# Altium schematic sheet DD_Current_Sense.SchDoc(DD_Current_Sense)
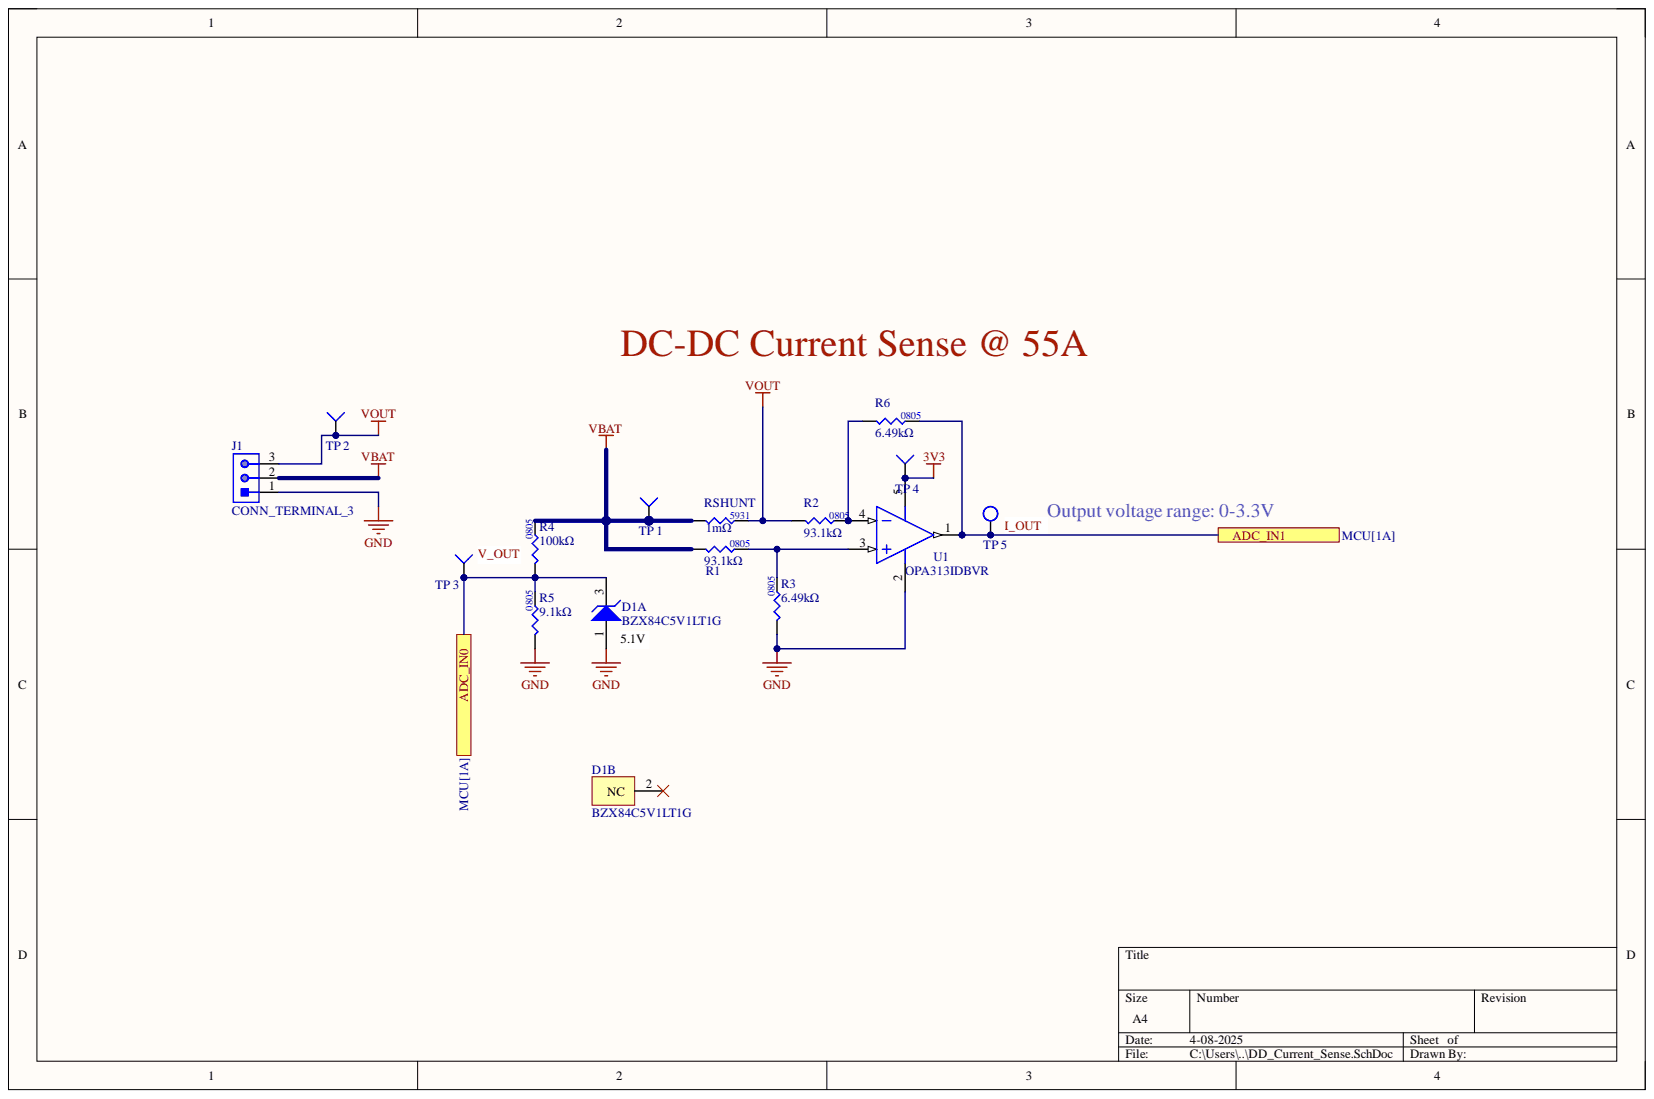
[diagram p0: DD_Current_Sense.SchDoc]

## Parts
D1  BZX84C5V1LT1G  [ONSC-SOT-23-3-318-08_V]  (parts A,B)
J1  CONN_TERMINAL_3  [WJ127-5.0-3P]
R1  value 93.1kΩ  Package/Footprint: 0805  [RES0805]  (hidden comment: RES_100Ω_0805_1%)
R2  value 93.1kΩ  Package/Footprint: 0805  [RES0805]  (hidden comment: RES_100Ω_0805_1%)
R3  value 6.49kΩ  Package/Footprint: 0805  [RES0805]  (hidden comment: RES_9.1kΩ_0805_5%)
R4  value 100kΩ  [RES0805]  (hidden comment: RES_100kΩ_0805_0.5%)
R5  value 9.1kΩ  Package: 0805  [RES0805]  (hidden comment: RES_9.1kΩ_0805_5%)
R6  value 6.49kΩ  Package/Footprint: 0805  [RES0805]  (hidden comment: RES_9.1kΩ_0805_5%)
RSHUNT  value 1mΩ  Package: 5931  [RES-5931]  (hidden comment: RES_1mΩ_5931_1%)
TP_1  TESTPOINT_1MM_CIRCLE  [TESTPOINT_CIRCLE_1MM]  (value not drawn)  (no BOM)
TP_2  TESTPOINT_1MM_CIRCLE  [TESTPOINT_CIRCLE_1MM]  (value not drawn)  (no BOM)
TP_3  TESTPOINT_1MM_CIRCLE  [TESTPOINT_CIRCLE_1MM]  (value not drawn)  (no BOM)
TP_4  TESTPOINT_1MM_CIRCLE  [TESTPOINT_CIRCLE_1MM]  (value not drawn)  (no BOM)
TP_5  TESTPOINT_LOOP  [TESTPOINT_LOOP]  (value not drawn)
U1  Manufacturer Part Number 1: OPA313IDBVR  [DBV0005A_M]  (hidden comment: OPAMP_OPA313IDBVR)

## Ports
ADC_IN0, ADC_IN1

## Nets
3V3: TP_4.1 U1.5
GND: D1.1 J1.1 R3.1 R5.1 U1.2
VBAT: J1.2 R1.1 R4.2 RSHUNT.1 TP_1.1
VOUT: J1.3 R2.2 RSHUNT.2 TP_2.1
ADC_IN0: D1.3 R4.1 R5.2 TP_3.1 ; port ADC_IN0
ADC_IN1: R6.2 TP_5.1 U1.1 ; port ADC_IN1
NetR1_2: R1.2 R3.2 U1.3
NetR2_1: R2.1 R6.1 U1.4

Not connected: D1 2(NC)

## Library data of the parts
D1 = CMP-1060-00946-1: Zener Voltage Regulator, 225 mW, 3-Pin SOT-23, Pb-Free, Tape and Reel; Manufacturer: On Semiconductor; Component Kind: Standard; ComponentLink1Description: Manufacturer URL; ComponentLink1URL: http://www.onsemi.com/; ComponentLink2Description: Datasheet; ComponentLink2URL: http://www.onsemi.com/pub_link/Collateral/BZX84C2V4LT1-D.PDF; ComponentLink3Description: Package Specification; ComponentLink3URL: http://www.onsemi.cn/pub_link/Collateral/318-08.PDF; DatasheetVersion: Rev. 17; Library Name: Altium Content Vault; Mounting Technology: Surface Mount; PackageDescription: 3-Pin Small Outline Transistor, Body 2.92 x 1.3 mm, Pitch 0.95 mm; PackageReference: SOT-23-3-318-08; PackageVersion: Rev. AP, 11/2009; Packing: Tape and Reel; PartNumber: BZX84C5V1LT1G; RoHS: Pb-Free
J1 = CONN_TERMINAL_3: Header, 3-Pin; Manufacturer 1: Ningbo Kangnex; Component Kind: Standard; Datasheet: https://datasheet.lcsc.com/lcsc/2107141401_Ningbo-Kangnex-Elec-WJ127-5-0-3P_C8393.pdf; LCSC Number: C8393; Manufaturer Part Number 1: WJ127-5.0-3P; Supplier 1: LCSC; Library Name: UWRT_Schematic_Library_2020.SchLib
R1 R2 = RES_93.1kΩ_0805_1%: RES 93.1K OHM 1% 1/8W 0805; Manufacturer 1: Panasonic Electronic Components; Manufacturer Part Number 1: ERJ-6ENF9312V; Component Kind: Standard; LCSC Part #: C17869; Mounting Type: SMD; Supplier 1: Digi-Key; Supplier Part Number 1: P93.1KCCT-ND; Library Name: UWRT_Schematic_Library_2020.SchLib
R3 R6 = RES_6.49kΩ_0805_1%: RES 6.49K OHM 1% 1/8W 0805; Manufacturer 1: Yageo; Manufacturer Part Number 1: RC0805FR-076K49L; Component Kind: Standard; Mounting Type: SMD; Supplier 1: Digi-Key; Supplier Part Number 1: 311-6.49KCRCT-ND; Library Name: UWRT_Schematic_Library_2020.SchLib
R4 = RES_100kΩ_0805_0.5%: RES 100K OHM 0.5% 1/8W 0805; Manufacturer 1: Panasonic; Manufacturer Part Number 1: ERA-6AED104V; Component Kind: Standard; Supplier 1: Digi-Key; Supplier Part Number 1: P123795CT-ND; Library Name: UWRT_Schematic_Library_2020.SchLib
R5 = RES_9.1kΩ_0805_5%: RES 9.1K OHM 5% 1/8W 0805; Manufacturer 1: Yageo; Manufacturer Part Number 1: RC0805JR-079K1L; Component Kind: Standard; Supplier 1: Digi-Key; Supplier Part Number 1: 311-9.1KARCT-ND; Tolerance: 5%; Library Name: UWRT_Schematic_Library_2020.SchLib
RSHUNT = RES_1mΩ_5931_1%: RES 0.001 OHM 1% 10W 5931; Manufacturer 1: Vishay Dale; Manufacturer Part Number 1: WSLP59311L000FEA; Component Kind: Standard; Mounting Type: SMD; Supplier 1: Digi-Key; Supplier Part Number 1: 541-10012-1-ND; Library Name: UWRT_Schematic_Library_2020.SchLib
TP_1-TP_4 = TESTPOINT_1MM_CIRCLE: TESTPOINT 1MM CIRCLE; Library Name: UWRT_Schematic_Library_2020.SchLib
TP_5 = TESTPOINT_LOOP: TESTPOINT THRUHOLE; Manufacturer 1: Keystone Electronics; Manufacturer Part Number 1: 5002; Package/Footprint: TH; Component Kind: Standard; Mounting Type: TH; Supplier 1: Digi-Key; Supplier Part Number 1: 36-5002-ND; Library Name: UWRT_Schematic_Library_2020.SchLib
U1 = OPAMP_OPA313IDBVR: IC OPAMP GP 1 CIRCUIT SOT23-5; Manufacturer 1: Texas Instruments; Component Kind: Standard; Mounting Type: SMD; Package: SOT-23-5; Supplier 1: Digi-Key; Supplier Part Number 1: 296-35607-1-ND; Verified: No; Library Name: UWRT_Schematic_Library_2020.SchLib
